# Altium schematic sheet MAIN_POWER.SchDoc(MAIN_POWER)
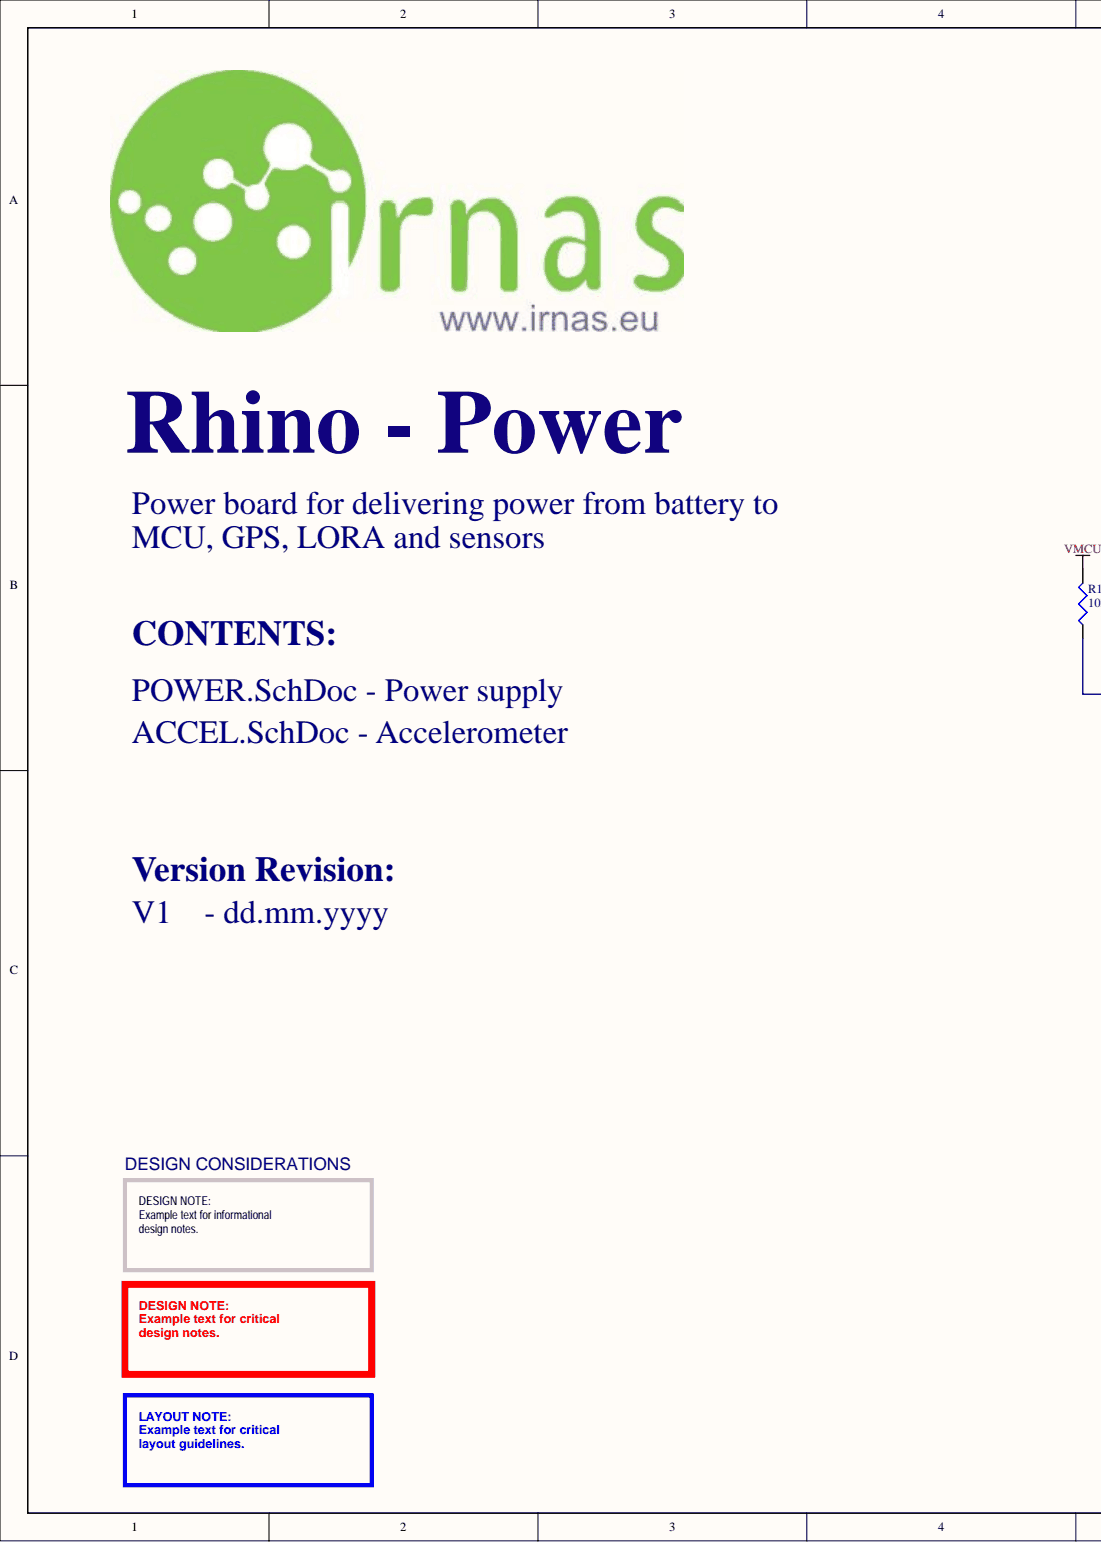
[diagram p0: MAIN_POWER.SchDoc, left]
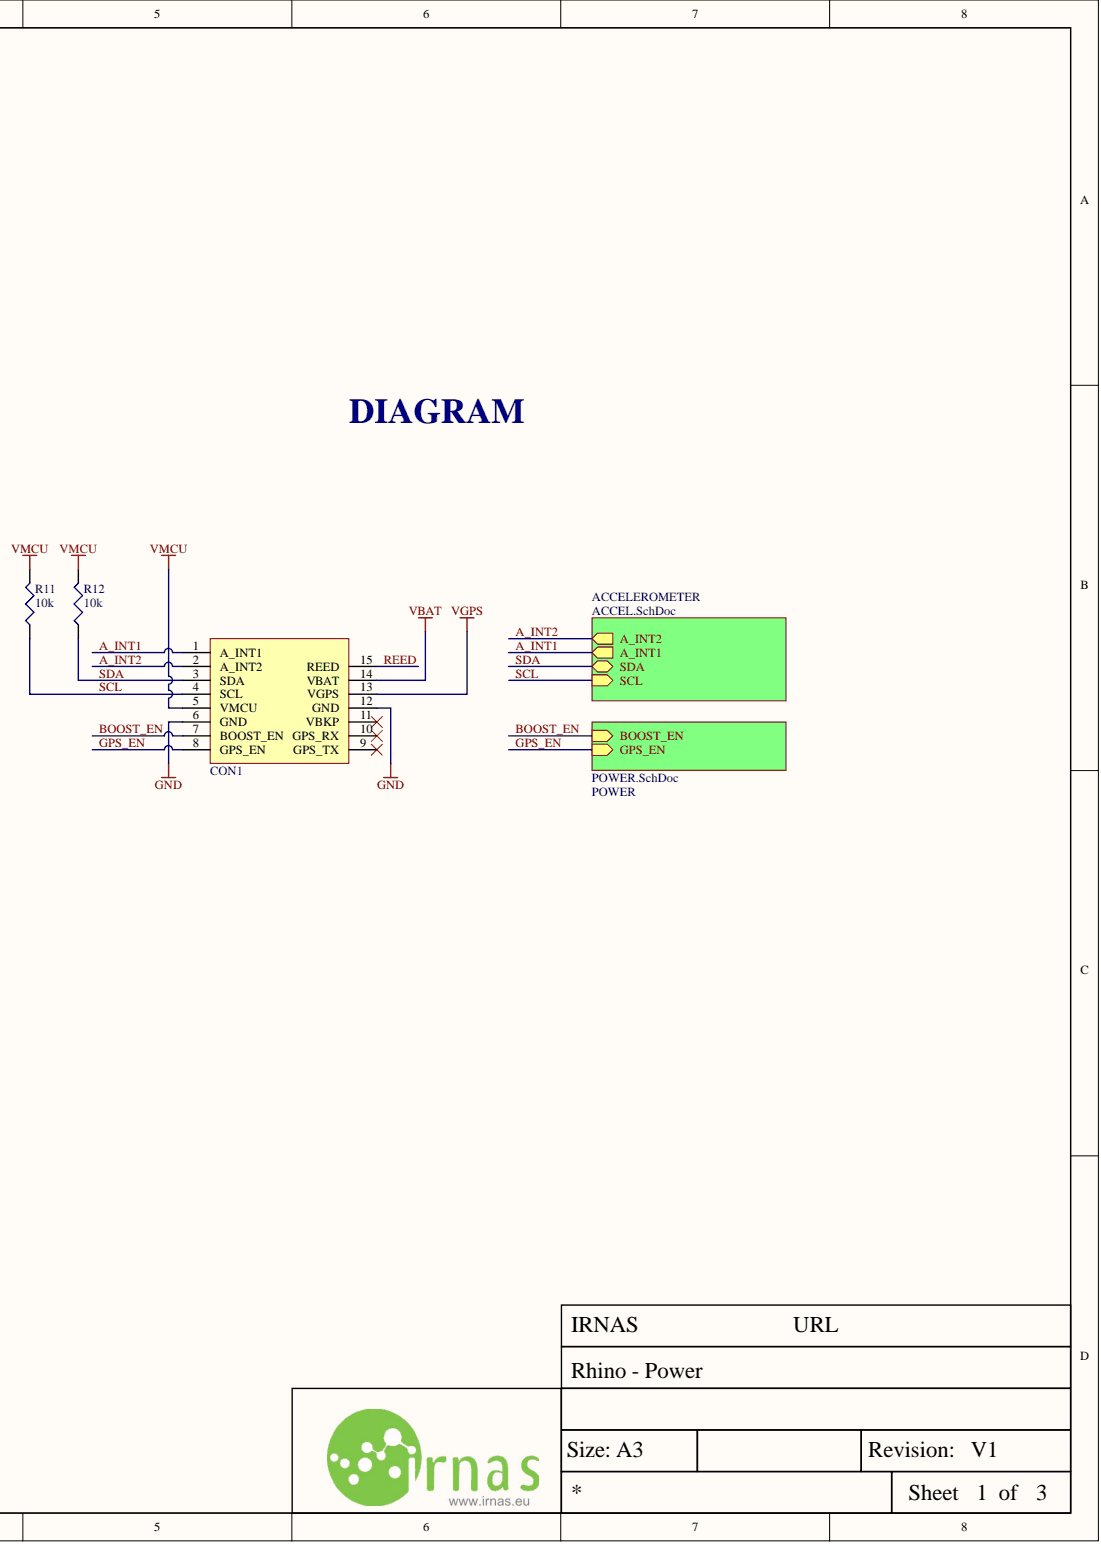
[diagram p1: MAIN_POWER.SchDoc, right]

## Parts
CON1  Between PCB connection  [SmartParks_Rhino]  (value not drawn)
R11  10k  [RES0402]
R12  10k  [RES0402]

## Nets
GND: CON1.6(GND) CON1.12(GND)
VBAT: CON1.14(VBAT)
VGPS: CON1.13(VGPS)
VMCU: CON1.5(VMCU) R11.2 R12.2
A_INT1: CON1.1(A_INT1)
A_INT2: CON1.2(A_INT2)
BOOST_EN: CON1.7(BOOST_EN)
GPS_EN: CON1.8(GPS_EN)
REED: CON1.15(REED)
SCL: CON1.4(SCL) R11.1
SDA: CON1.3(SDA) R12.1
NetCON1_9: CON1.9(GPS_TX)
NetCON1_10: CON1.10(GPS_RX)
NetCON1_11: CON1.11(VBKP)

## Library data of the parts
CON1 = SmartParks_Rhino: Library Name: Connectors.SchLib; Description: Between PCB connection; Component Kind: Standard
R11 R12 = R - 10k/0402: MULTICOMP         MCWR04X1002FTL             SMD Chip Resistor, Thick Film, 10 kohm, 50 V, 0402 [1005 Metric], 62.5 mW,  1%, MCWR Series; IRNAS Part No.: I-100014; Voltage Rating (DC): 50V; Temperature Coefficient: 100ppm/°C; Resistance: 10kR; Power Rating: 62.5mW; Voltage Rating: 50V; Tolerance: 1%; Supplier Part Number 1: 2447096; Supplier 1: Farnell; Stock 1: 602609; REACH SVHC: No SVHC; Pricing 1: 10=0.0029, 100=0.0017, 500=0.0012, 2500=0.001, 5000=0.0008 (EUR); Packaging: Cut Tape; Manufacturer Part Number: MCWR04X1002FTL; Manufacturer: Multicomp; Library Name: Passive.SchLib; Composition: Thick Film; ComponentLink1URL: http://www.farnell.com/datasheets/1849611.pdf; ComponentLink1Description: http://www.farnell.com/datasheets/1849611.pdf; Component Kind: Standard; Case Code (Metric): 1005; Case Code (Imperial): 0402; Case/Package: 0402
